# Altium schematic sheet Schematic.SchDoc(Schematic)
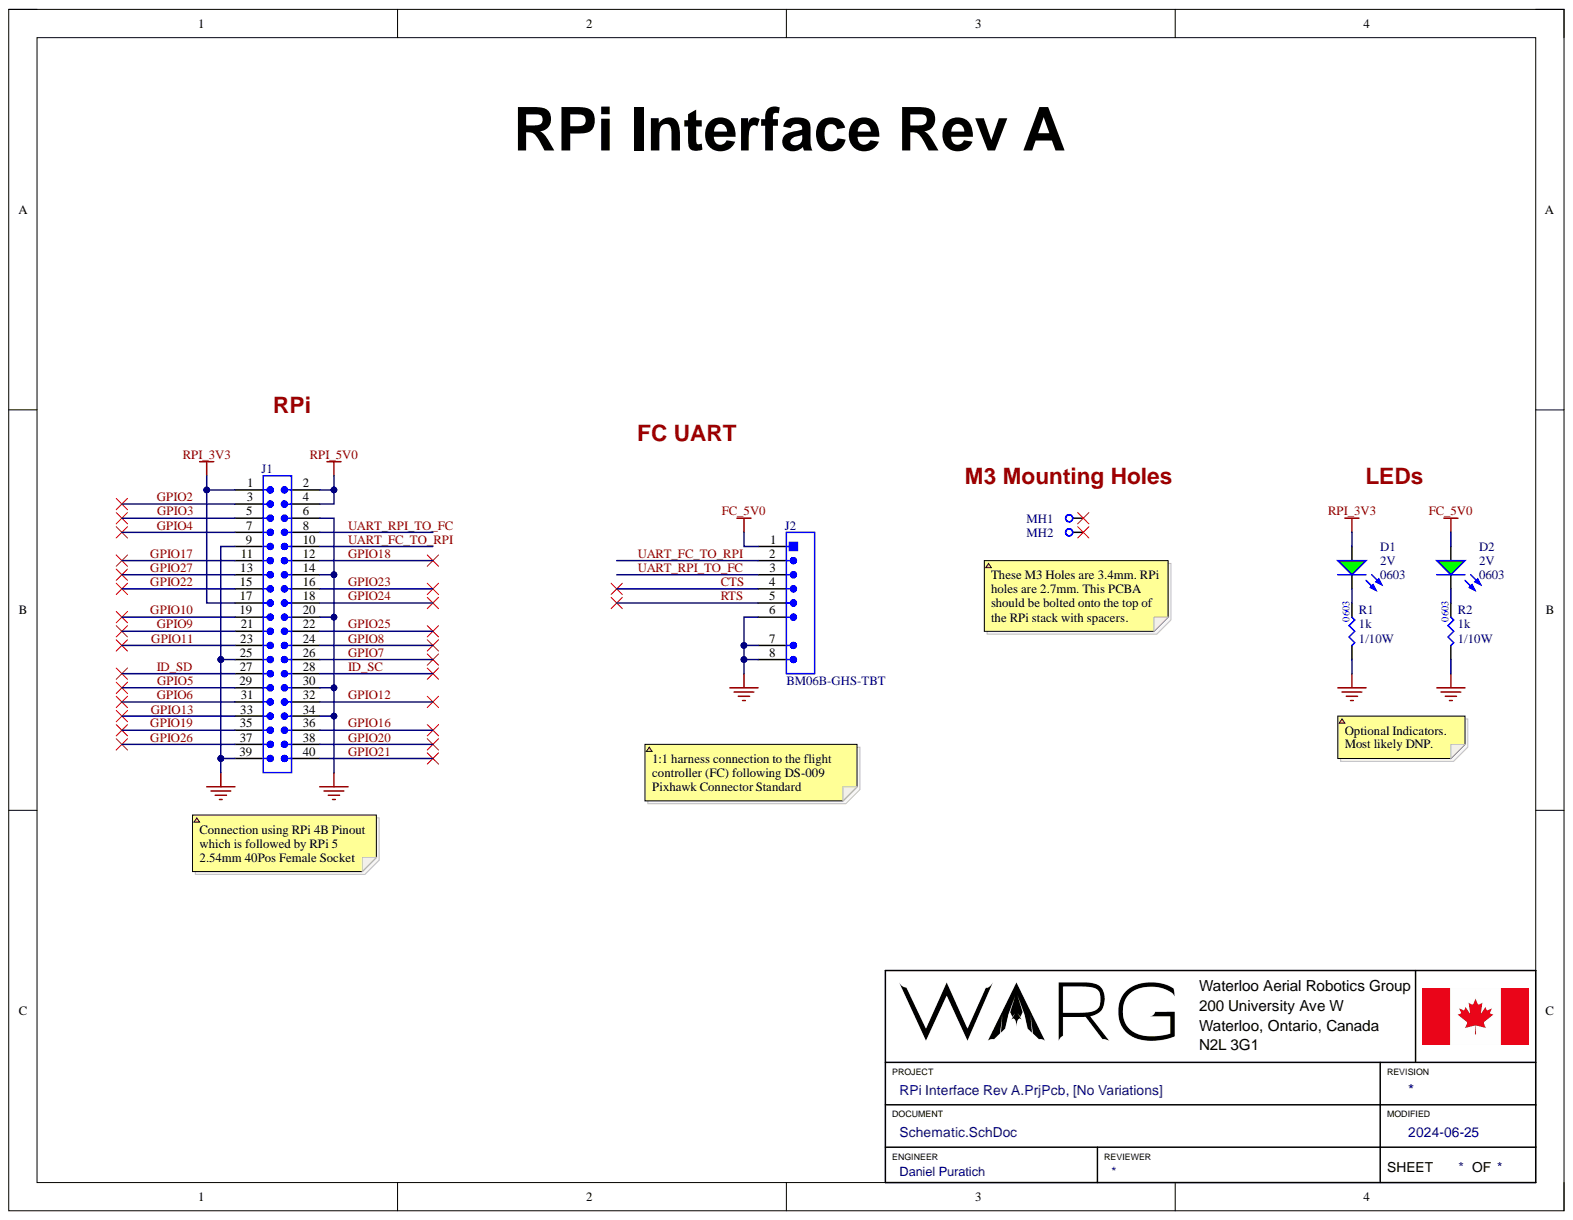
[diagram p0: Schematic.SchDoc]

## Parts
D1  Case/Package: 0603  Forward Voltage: 2V  [.LED_0603-Footprint-1]  (hidden comment: LTST-C191KGKT)
D2  Case/Package: 0603  Forward Voltage: 2V  [.LED_0603-Footprint-1]  (hidden comment: LTST-C191KGKT)
J1  PPPC202LFBN-RC  [PPPC202LFBN-RC-Footprint-1]  (value not drawn)
J2  BM06B-GHS-TBT  [BM06B-GHS-TBT-Footprint-1]
MH1  M3HOLE-STANDARD  [M3HOLE-STANDARD-Footprint-1]  (value not drawn)
MH2  M3HOLE-STANDARD  [M3HOLE-STANDARD-Footprint-1]  (value not drawn)
R1  value 1k  Case/Package: 0603  Power: 1/10W  [.RES_0603-Footprint-1]  (hidden comment: RC0603FR-071KL)
R2  value 1k  Case/Package: 0603  Power: 1/10W  [.RES_0603-Footprint-1]  (hidden comment: RC0603FR-071KL)

## Nets
FC_5V0: D2.1 J2.1
GND: J1.6 J1.9 J1.14 J1.20 J1.25 J1.30 J1.34 J1.39 J2.6 J2.7 J2.8 R1.1 R2.1
RPI_3V3: D1.1 J1.1 J1.17
RPI_5V0: J1.2 J1.4
CTS: J2.4
GPIO2: J1.3
GPIO3: J1.5
GPIO4: J1.7
GPIO5: J1.29
GPIO6: J1.31
GPIO7: J1.26
GPIO8: J1.24
GPIO9: J1.21
GPIO10: J1.19
GPIO11: J1.23
GPIO12: J1.32
GPIO13: J1.33
GPIO16: J1.36
GPIO17: J1.11
GPIO18: J1.12
GPIO19: J1.35
GPIO20: J1.38
GPIO21: J1.40
GPIO22: J1.15
GPIO23: J1.16
GPIO24: J1.18
GPIO25: J1.22
GPIO26: J1.37
GPIO27: J1.13
ID_SC: J1.28
ID_SD: J1.27
RTS: J2.5
UART_FC_TO_RPI: J1.10 J2.2
UART_RPI_TO_FC: J1.8 J2.3
NetD1_2: D1.2 R1.2
NetD2_2: D2.2 R2.2

Not connected: MH1 1; MH2 1

## Library data of the parts
D1 D2 = CMP-001-00018-6: LED GREEN 2V 0603; Forward Current: 20mA; Color: Green; Component Kind: Standard; Library Name: WARG
J1 = CMP-00254-1: CONN HDR 40POS 0.1 GOLD PCB; Component Kind: Standard; Library Name: WARG
J2 = CMP-002-00014-6: Connector Header Surface Mount 6 position 0.049" (1.25mm); Mfr Name: JST; Component Kind: Standard; Design Item ID: BM06B-GHS-TBT; Library Name: WARG
MH1 MH2 = CMP-00005-4: Standard M3 Mounting hole as defined by mech team, see confluence for reasonning; Hole Diam: 3.4; Plating Diam: 6; Component Kind: Standard; Library Name: WARG
R1 R2 = CMP-009-00012-3: RES 1k OHM 1% 1/10W 0603; Component Kind: Standard; Library Name: WARG
